# Altium schematic sheet Sheet2.SchDoc
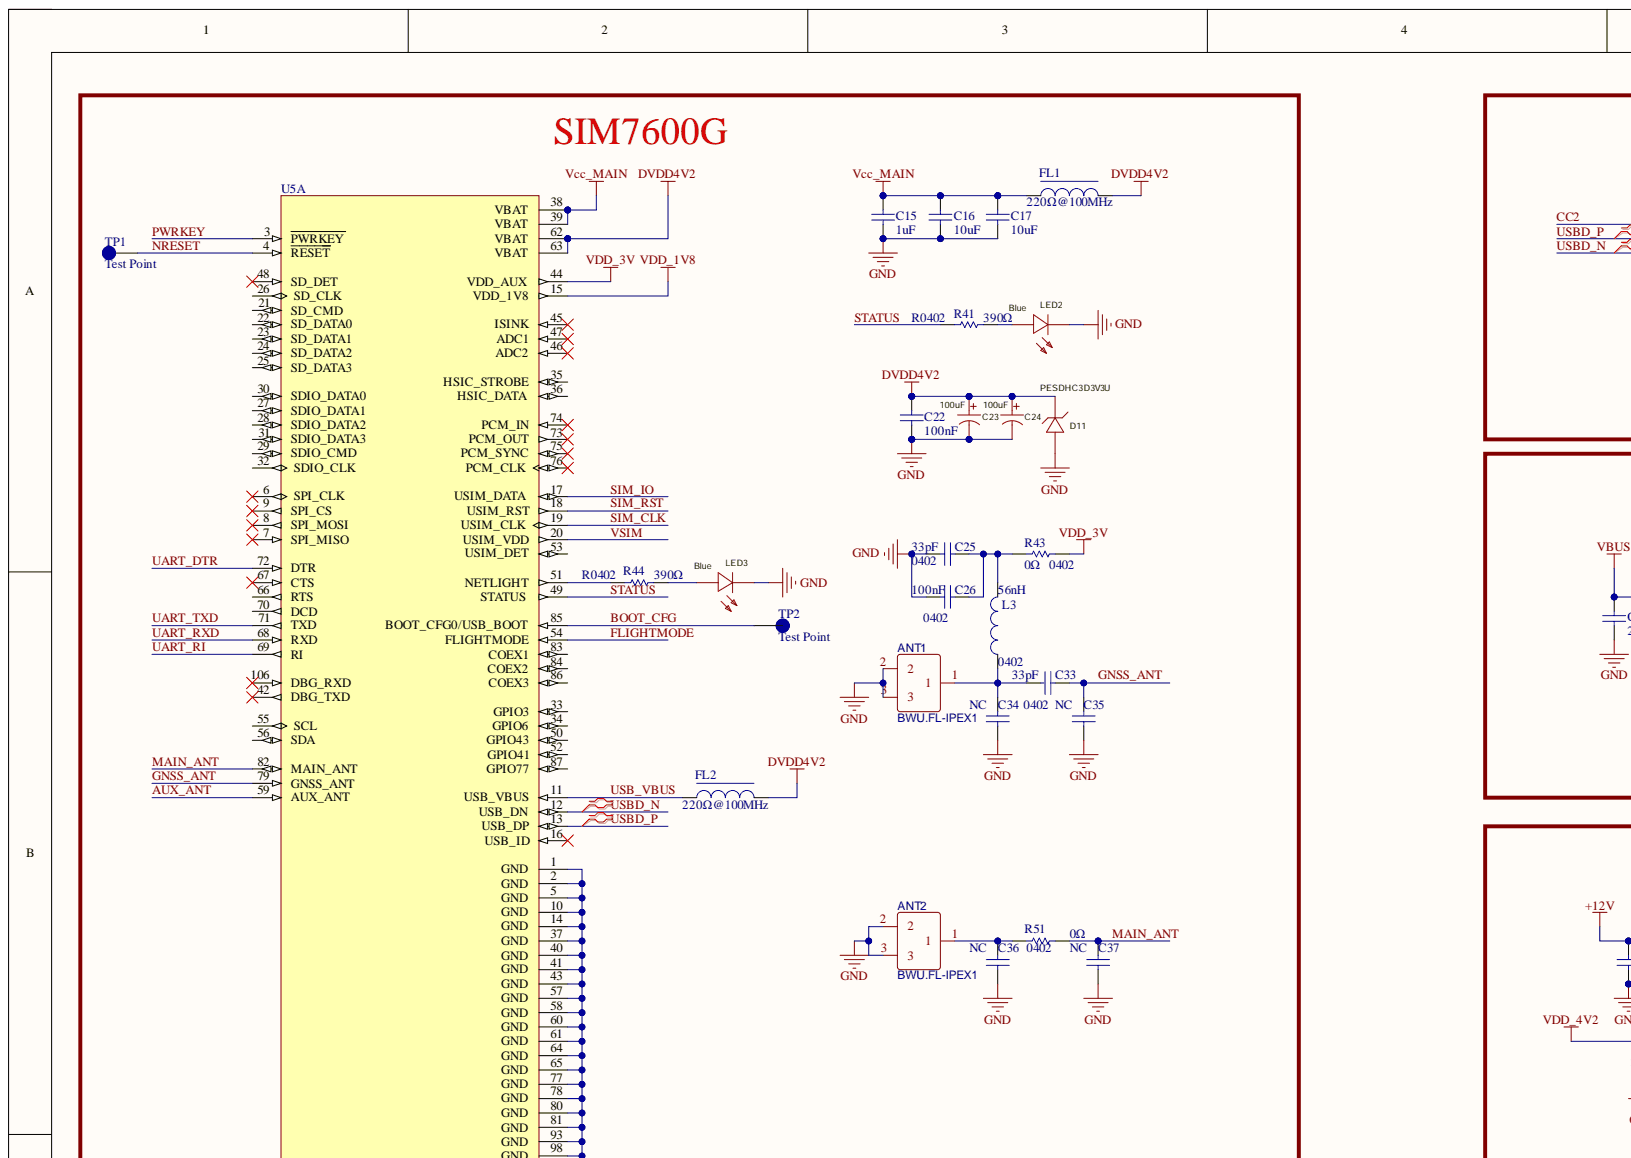
[diagram p0: Sheet2.SchDoc, top left]
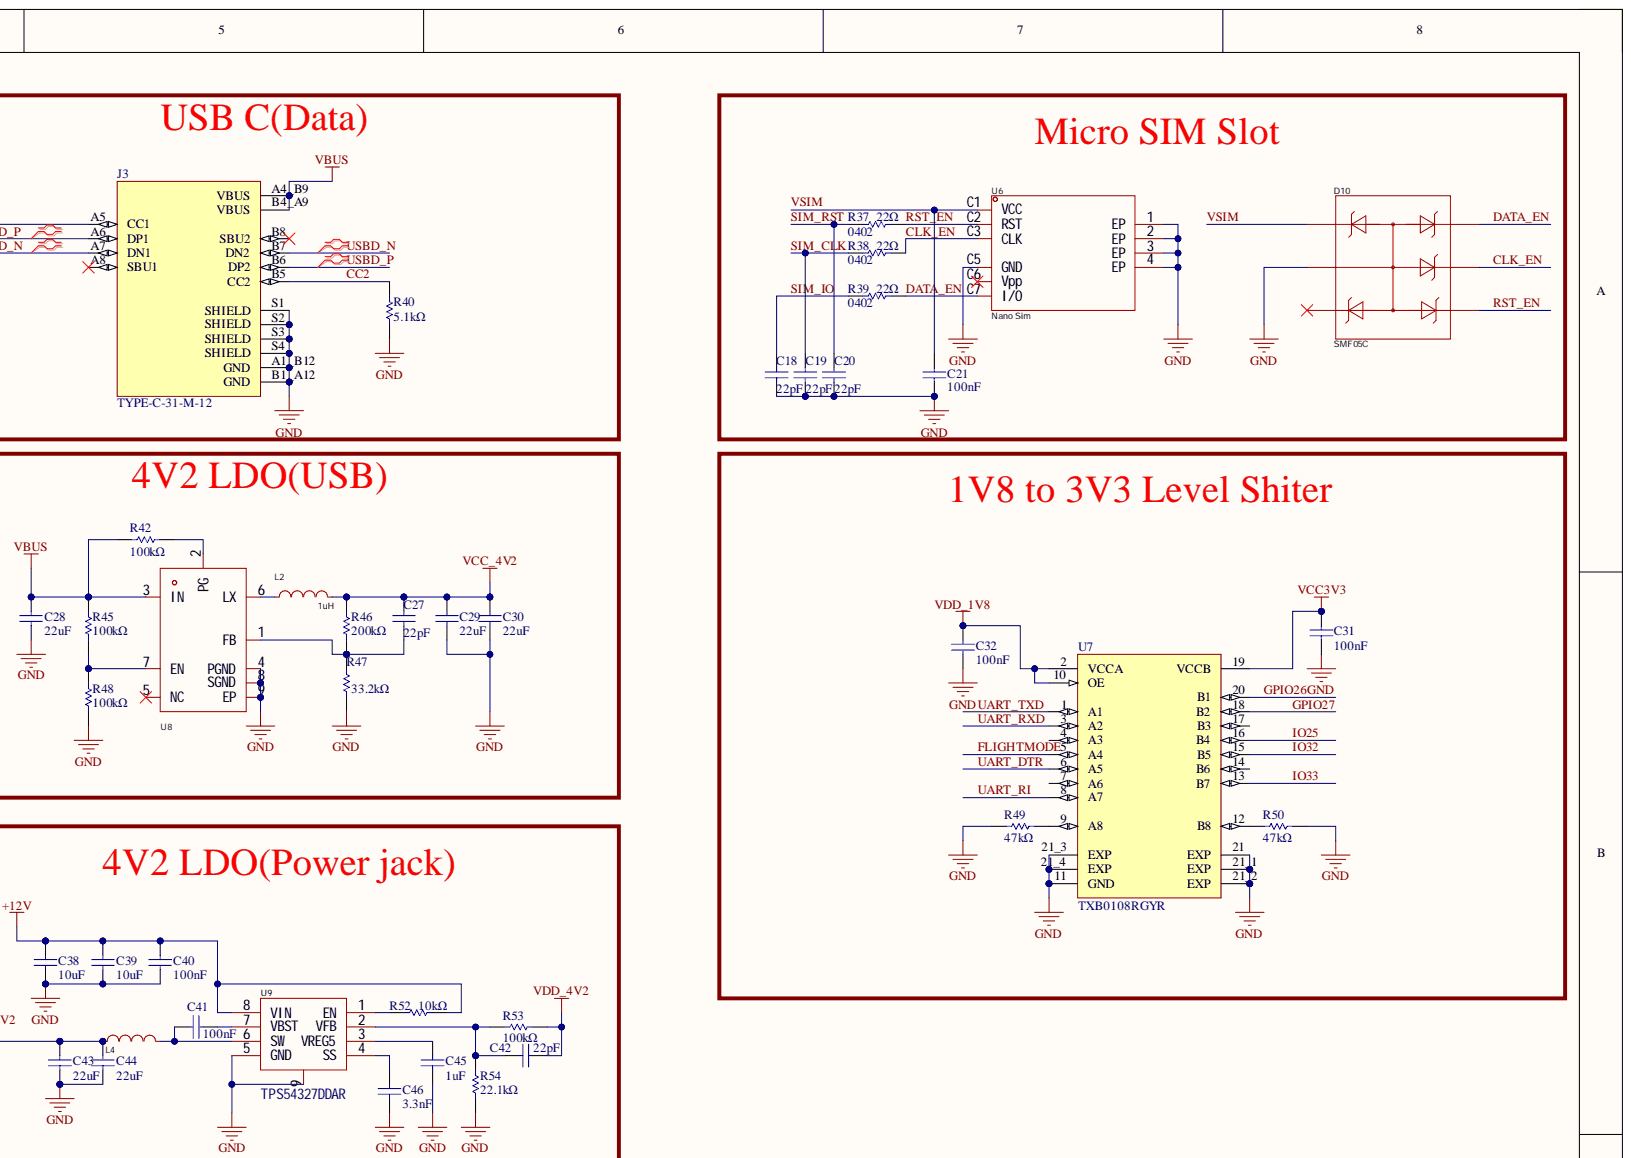
[diagram p1: Sheet2.SchDoc, top right]
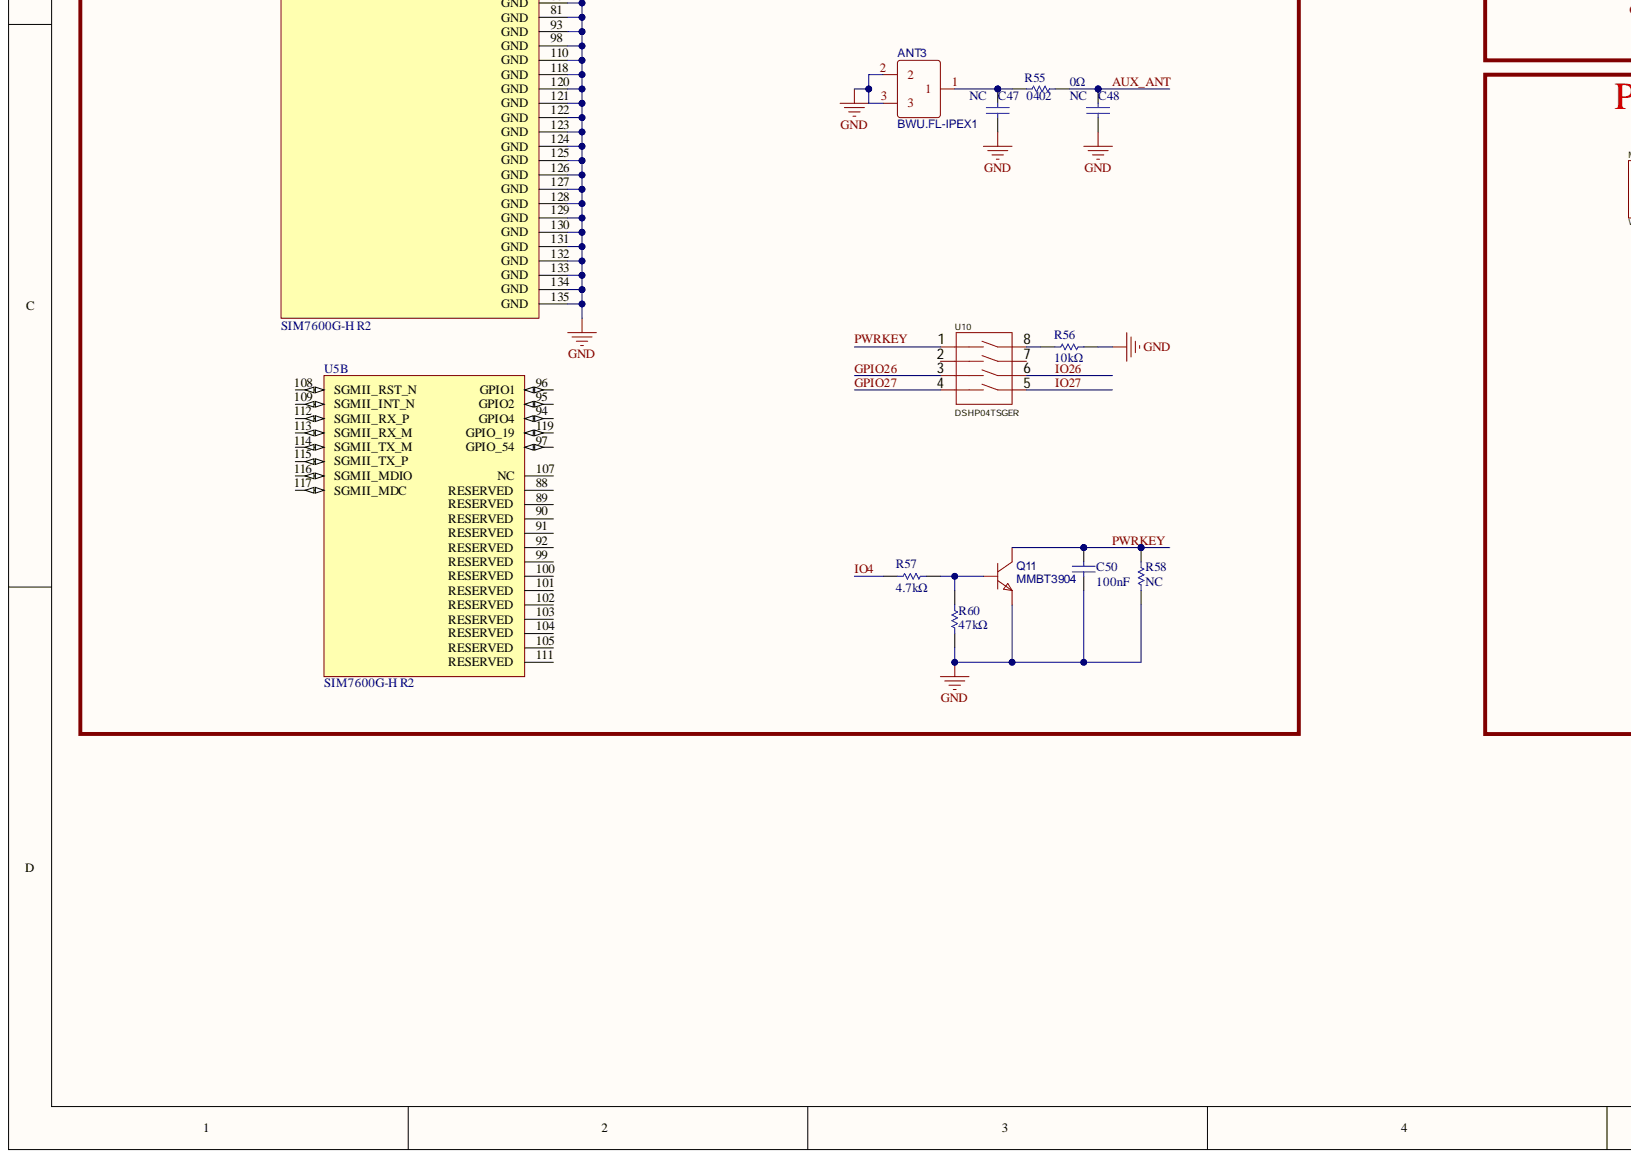
[diagram p2: Sheet2.SchDoc, bottom left]
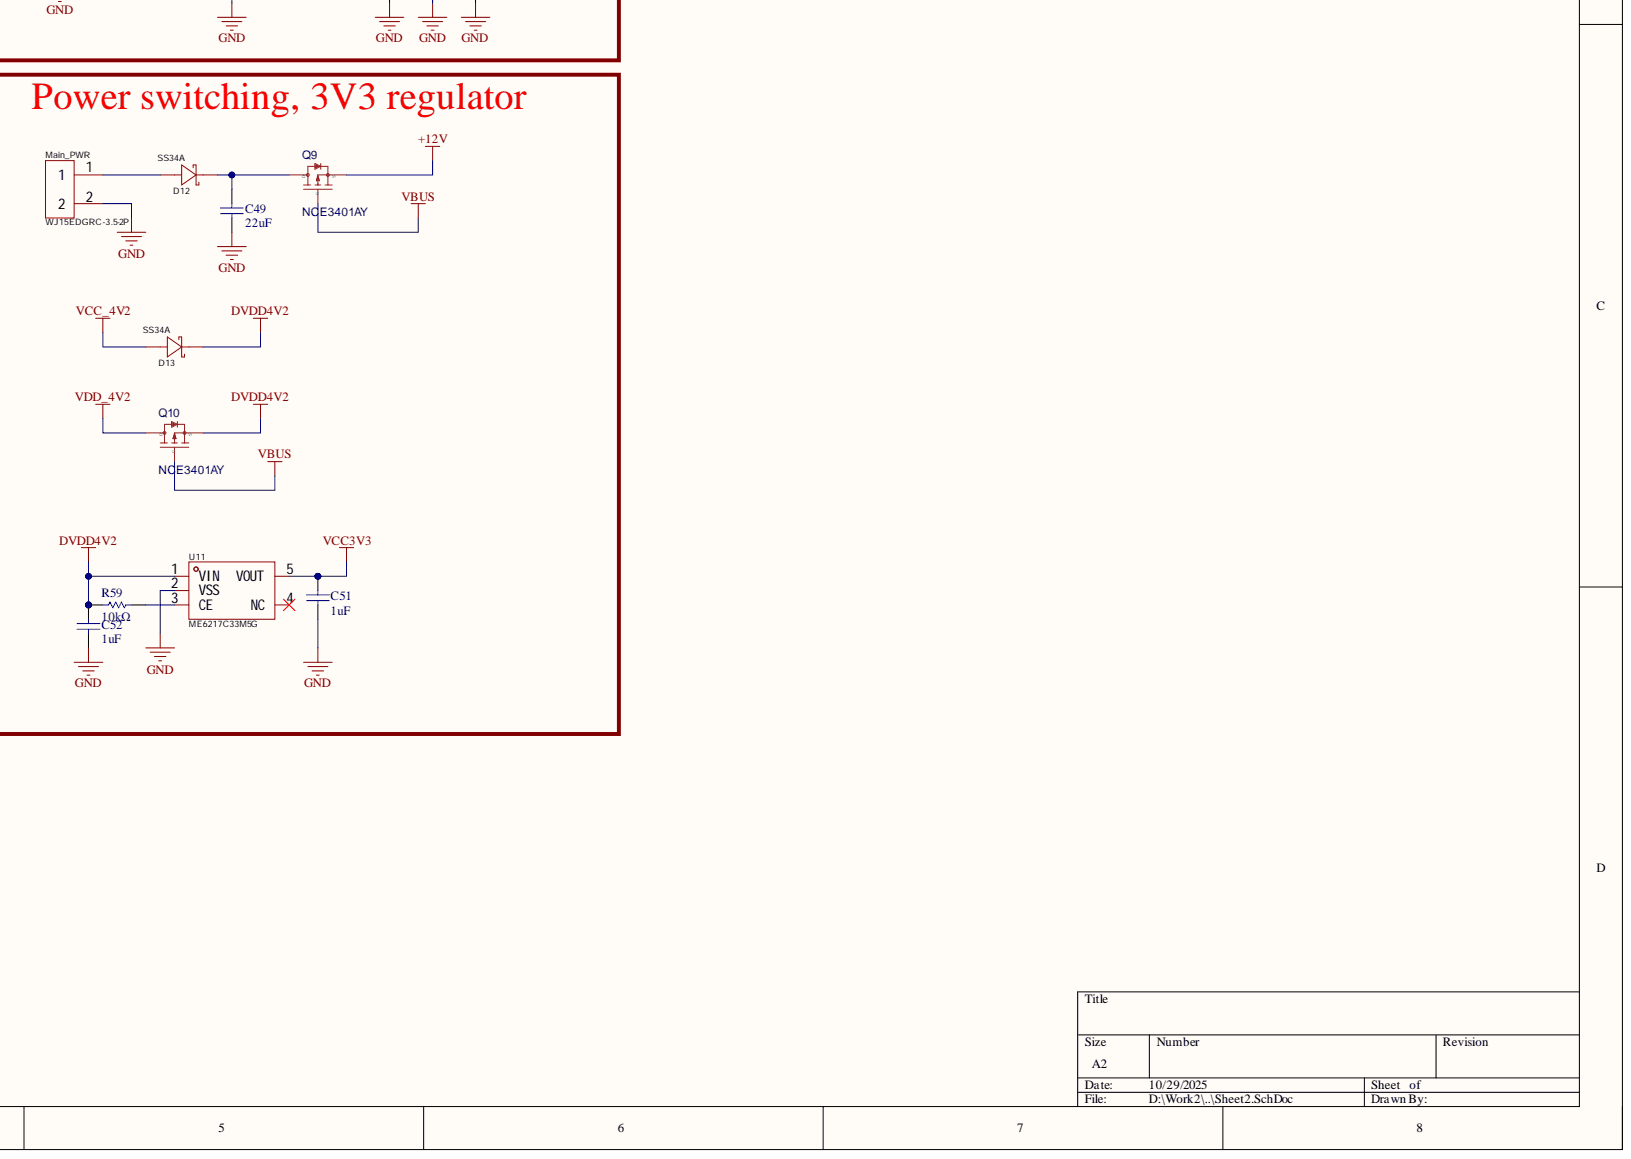
[diagram p3: Sheet2.SchDoc, bottom right]

## Parts
ANT1  BWU.FL-IPEX1  [IPEX-SMD_BWIPX-1-001E]
ANT2  BWU.FL-IPEX1  [IPEX-SMD_BWIPX-1-001E]
ANT3  BWU.FL-IPEX1  [IPEX-SMD_BWIPX-1-001E]
C15  value 1uF  [C0603]  (hidden comment: Cap)
C16  value 10uF  [C0603]  (hidden comment: Cap)
C17  value 10uF  [C0603]  (hidden comment: Cap)
C18  value 22pF  [C0603]  (hidden comment: Cap)
C19  value 22pF  [C0603]  (hidden comment: Cap)
C20  value 22pF  [C0603]  (hidden comment: Cap)
C21  value 100nF  [C0603]  (hidden comment: Cap)
C22  value 100nF  [C0603]  (hidden comment: Cap)
C23  100uF  [CAP-TH_BD6.3-P2.50-D1.0-FD]
C24  100uF  [CAP-TH_BD6.3-P2.50-D1.0-FD]
C25  value 33pF  Package: 0402  [C0402]  (hidden comment: Cap)
C26  value 100nF  C14663: 0402  [C0402]  (hidden comment: Cap)
C27  value 22pF  [C0603]  (hidden comment: Cap)
C28  value 22uF  [L0805]  (hidden comment: Cap)
C29  value 22uF  [L0805]  (hidden comment: Cap)
C30  value 22uF  [L0805]  (hidden comment: Cap)
C31  value 100nF  [C0603]  (hidden comment: Cap)
C32  value 100nF  [C0603]  (hidden comment: Cap)
C33  value 33pF  Package: 0402  [C0402]  (hidden comment: Cap)
C34  Mfr. Part #: NC  Package: 0402  [C0402]  (hidden comment: Cap)
C35  Mfr. Part #: NC  [C0402]  (hidden comment: Cap)
C36  Mfr. Part #: NC  [C0402]  (hidden comment: Cap)
C37  Mfr. Part #: NC  [C0402]  (hidden comment: Cap)
C38  value 10uF  [C0603]  (hidden comment: Cap)
C39  value 10uF  [C0603]  (hidden comment: Cap)
C40  value 100nF  [C0603]  (hidden comment: Cap)
C41  value 100nF  [C0603]  (hidden comment: Cap)
C42  value 22pF  [C0603]  (hidden comment: Cap)
C43  value 22uF  [L0805]  (hidden comment: Cap)
C44  value 22uF  [L0805]  (hidden comment: Cap)
C45  value 1uF  [C0603]  (hidden comment: Cap)
C46  value 3.3nF  [C0603]  (hidden comment: Cap)
C47  Mfr. Part #: NC  [C0402]  (hidden comment: Cap)
C48  Mfr. Part #: NC  [C0402]  (hidden comment: Cap)
C49  value 22uF  [L0805]  (hidden comment: Cap)
C50  value 100nF  [C0603]  (hidden comment: Cap)
C51  value 1uF  [C0603]  (hidden comment: Cap)
C52  value 1uF  [C0603]  (hidden comment: Cap)
D10  SMF05C  [SC-70-6_L2.0-W1.3-P0.65-LS2.1-BR]
D11  PESDHC3D3V3U  [SOD-323_L1.8-W1.3-LS2.5-RD]
D12  SS34A  [SMA_L4.2-W2.6-LS5.3-R-RD]
D13  SS34A  [SMA_L4.2-W2.6-LS5.3-R-RD]
FL1  value 220Ω@100MHz  [BEADC1608X95N]  (hidden comment: BLM18PG221SH1D)
FL2  value 220Ω@100MHz  [BEADC1608X95N]  (hidden comment: BLM18PG221SH1D)
J3  TYPE-C-31-M-12  [HRO_TYPE-C-31-M-12]
L2  1uH  [IND-SMD_L4.0-W4.0_SWPA4018S]
L3  value 56nH  Package: 0402  [L0402]
L4  3.3uH  [IND-SMD_L5.8-W5.2]  (value not drawn)
LED2  value Blue  [LED-SMD_L1.6-W0.8-RD-BLUE]  (hidden comment: EKINGLUX')
LED3  value Blue  [LED-SMD_L1.6-W0.8-RD-BLUE]  (hidden comment: EKINGLUX')
Main_PWR  WJ15EDGRC-3.5-2P  [CONN-TH_WJ15EDGRC-3.5-2P-14-00A]
Q9  NCE3401AY  [SOT-23_L2.9-W1.3-P1.90-LS2.4-BR]
Q10  NCE3401AY  [SOT-23_L2.9-W1.3-P1.90-LS2.4-BR]
Q11  MMBT3904  [SOT-23-3_L2.9-W1.3-P1.90-LS2.4-BR]
R37  value 22Ω  Package: 0402  [R0402]  (hidden comment: Res1)
R38  value 22Ω  Package: 0402  [R0402]  (hidden comment: Res1)
R39  value 22Ω  Package: 0402  [R0402]  (hidden comment: Res1)
R40  value 5.1kΩ  [R0603]  (hidden comment: Res1)
R41  R0402  value 390Ω  [R0402]
R42  value 100kΩ  [R0603]  (hidden comment: Res1)
R43  value 0Ω  Package: 0402  [R0402]  (hidden comment: Res1)
R44  R0402  value 390Ω  [R0402]
R45  value 100kΩ  [R0603]  (hidden comment: Res1)
R46  value 200kΩ  [R0603]  (hidden comment: Res1)
R47  value 33.2kΩ  [R0603]  (hidden comment: Res1)
R48  value 100kΩ  [R0603]  (hidden comment: Res1)
R49  value 47kΩ  [R0603]  (hidden comment: Res1)
R50  value 47kΩ  [R0603]  (hidden comment: Res1)
R51  value 0Ω  Package: 0402  [R0402]  (hidden comment: Res1)
R52  value 10kΩ  [R0603]  (hidden comment: Res1)
R53  value 100kΩ  [R0603]  (hidden comment: Res1)
R54  value 22.1kΩ  [R0603]  (hidden comment: Res1)
R55  value 0Ω  Package: 0402  [R0402]  (hidden comment: Res1)
R56  value 10kΩ  [R0603]  (hidden comment: Res1)
R57  value 4.7kΩ  [R0603]  (hidden comment: Res1)
R58  value NC  Mfr. Part #: NC  [R0603]  (hidden comment: Res1)
R59  value 10kΩ  [R0603]  (hidden comment: Res1)
R60  value 47kΩ  [R0603]  (hidden comment: Res1)
TP1  Test Point  [Test Point]  (no BOM)
TP2  Test Point  [Test Point]  (no BOM)
U5  SIM7600G-H R2  [IC_SIM7600G]  (parts A,B)
U6  value Nano Sim  [SIM-SMD_SMN-305]  (hidden comment: SMN-305)
U7  TXB0108RGYR  [IC_TXB0108RGYR]
U8  SY8003C1DFC  [WDFN-8_L2.0-W2.0-P0.50-BL-EP]  (value not drawn)
U9  TPS54327DDAR  [HSOP-8_L5.0-W4.0-P1.27-LS6.2-BL-EP]
U10  DSHP04TSGER  [SW-SMD_8P-L6.7-W5.4-P1.27-LS8.4]
U11  ME6217C33M5G  [SOT-23-5_L3.0-W1.7-P0.95-LS2.8-BR]

## Nets
+12V: C38.2 C39.2 C40.2 Q9.2 R52.1 U9.8(VIN)
DVDD4V2: C22.2 C23.1 C24.1 C52.2 D11.1 D13.2 FL1.2 FL2.2 Q10.2 R59.1 U5.62(VBAT) U5.63(VBAT) U11.1(VIN)
GND: ANT1.2 ANT1.3 ANT2.2 ANT2.3 ANT3.2 ANT3.3 C15.1 C16.1 C17.1 C18.2 C19.2 C20.2 C21.2 C22.1 C23.2 C24.2 C25.2 C26.2 C28.1 C29.1 C30.1 C31.2 C32.2 C34.2 C35.2 C36.2 C37.2 C38.1 C39.1 C40.1 C43.1 C44.1 C45.1 C46.1 C47.2 C48.2 C49.1 C50.2 C51.1 C52.1 D10.2 D11.2 J3.A1_B12(GND) J3.B1_A12(GND) J3.S1(SHIELD) J3.S2(SHIELD) J3.S3(SHIELD) J3.S4(SHIELD) LED2.1 LED3.1 Main_PWR.2 Q11.2 R40.1 R47.2 R48.1 R49.1 R50.2 R54.2 R56.2 R58.2 R60.2 U5.1(GND) U5.2(GND) U5.5(GND) U5.10(GND) U5.14(GND) U5.37(GND) U5.40(GND) U5.41(GND) U5.43(GND) U5.57(GND) U5.58(GND) U5.60(GND) U5.61(GND) U5.64(GND) U5.65(GND) U5.77(GND) U5.78(GND) U5.80(GND) U5.81(GND) U5.93(GND) U5.98(GND) U5.110(GND) U5.118(GND) U5.120(GND) U5.121(GND) U5.122(GND) U5.123(GND) U5.124(GND) U5.125(GND) U5.126(GND) U5.127(GND) U5.128(GND) U5.129(GND) U5.130(GND) U5.131(GND) U5.132(GND) U5.133(GND) U5.134(GND) U5.135(GND) U6.1(EP) U6.2(EP) U6.3(EP) U6.4(EP) U6.C5(GND) U7.11(GND) U7.21(EXP) U7.21_1(EXP) U7.21_2(EXP) U7.21_3(EXP) U7.21_4(EXP) U8.4(PGND) U8.8(SGND) U8.9(EP) U9.5(GND) U9.9 U11.2(VSS)
VBUS: C28.2 J3.A4_B9(VBUS) J3.B4_A9(VBUS) Q9.1 Q10.1 R42.2 R45.2 U8.3(IN)
VCC3V3: C31.1 C51.2 U7.19(VCCB) U11.5(VOUT)
VCC_4V2: C27.1 C29.2 C30.2 D13.1 L2.2 R46.1
Vcc_MAIN: C15.2 C16.2 C17.2 FL1.1 U5.38(VBAT) U5.39(VBAT)
VDD_1V8: C32.1 U5.15(VDD_1V8) U7.2(VCCA) U7.10(OE)
VDD_3V: R43.1 U5.44(VDD_AUX)
VDD_4V2: C42.2 C43.2 C44.2 L4.1 Q10.3 R53.1
AUX_ANT: C48.1 R55.1 U5.59(AUX_ANT)
BOOT_CFG: TP2.TP U5.85(BOOT_CFG0/USB_BOOT)
CC2: J3.A5(CC1) J3.B5(CC2) R40.2
CLK_EN: D10.5 R38.1 U6.C3(CLK)
DATA_EN: D10.6 R39.1 U6.C7(I/O)
FLIGHTMODE: U5.54(FLIGHTMODE) U7.5(A4)
GNSS_ANT: C33.1 C35.1 U5.79(GNSS_ANT)
GPIO26: U7.20(B1) U10.3
GPIO27: U7.18(B2) U10.4
IO4: R57.2
IO25: U7.16(B4)
IO26: U10.6
IO27: U10.5
IO32: U7.15(B5)
IO33: U7.13(B7)
MAIN_ANT: C37.1 R51.1 U5.82(MAIN_ANT)
NRESET: TP1.TP U5.4(RESET)
PWRKEY: C50.1 Q11.3 R58.1 U5.3(PWRKEY) U10.1
RST_EN: D10.4 R37.1 U6.C2(RST)
SIM_CLK: C19.1 R38.2 U5.19(USIM_CLK)
SIM_IO: C18.1 R39.2 U5.17(USIM_DATA)
SIM_RST: C20.1 R37.2 U5.18(USIM_RST)
STATUS: R41.2 U5.49(STATUS)
UART_DTR: U5.72(DTR) U7.6(A5)
UART_RI: U5.69(RI) U7.8(A7)
UART_RXD: U5.68(RXD) U7.3(A2)
UART_TXD: U5.71(TXD) U7.1(A1)
USB_VBUS: FL2.1 U5.11(USB_VBUS)
USBD_N: J3.A7(DN1) J3.B7(DN2) U5.12(USB_DN)
USBD_P: J3.A6(DP1) J3.B6(DP2) U5.13(USB_DP)
VSIM: C21.1 D10.1 U5.20(USIM_VDD) U6.C1(VCC)
NetANT1_1: ANT1.1 C33.2 C34.1 L3.1
NetANT2_1: ANT2.1 C36.1 R51.2
NetANT3_1: ANT3.1 C47.1 R55.2
NetC25_1: C25.1 C26.1 L3.2 R43.2
NetC27_2: C27.2 R46.2 R47.1 U8.1(FB)
NetC41_1: C41.1 U9.7(VBST)
NetC41_2: C41.2 L4.2 U9.6(SW)
NetC42_1: C42.1 R53.2 R54.1 U9.2(VFB)
NetC45_2: C45.2 U9.3(VREG5)
NetC46_2: C46.2 U9.4(SS)
NetC49_2: C49.2 D12.2 Q9.3
NetD12_1: D12.1 Main_PWR.1
NetL2_1: L2.1 U8.6(LX)
NetLED2_2: LED2.2 R41.1
NetLED3_2: LED3.2 R44.1
NetQ11_1: Q11.1 R57.1 R60.1
NetR42_1: R42.1 U8.2(PG)
NetR44_2: R44.2 U5.51(NETLIGHT)
NetR45_1: R45.1 R48.2 U8.7(EN)
NetR49_2: R49.2 U7.9(A8)
NetR50_1: R50.1 U7.12(B8)
NetR52_2: R52.2 U9.1(EN)
NetR56_1: R56.1 U10.8
NetR59_2: R59.2 U11.3(CE)

Not connected: D10 3; J3 A8(SBU1) B8(SBU2); U5 6(SPI_CLK) 7(SPI_MISO) 8(SPI_MOSI) 9(SPI_CS) 16(USB_ID) 21(SD_CMD) 22(SD_DATA0) 23(SD_DATA1) 24(SD_DATA2) 25(SD_DATA3) 26(SD_CLK) 27(SDIO_DATA1) 28(SDIO_DATA2) 29(SDIO_CMD) 30(SDIO_DATA0) 31(SDIO_DATA3) 32(SDIO_CLK) 33(GPIO3) 34(GPIO6) 35(HSIC_STROBE) 36(HSIC_DATA) 42(DBG_TXD) 45(ISINK) 46(ADC2) 47(ADC1) 48(SD_DET) 50(GPIO43) 52(GPIO41) 53(USIM_DET) 55(SCL) 56(SDA) 66(RTS) 67(CTS) 70(DCD) 73(PCM_OUT) 74(PCM_IN) 75(PCM_SYNC) 76(PCM_CLK) 83(COEX1) 84(COEX2) 86(COEX3) 87(GPIO77) 88(RESERVED) 89(RESERVED) 90(RESERVED) 91(RESERVED) 92(RESERVED) 94(GPIO4) 95(GPIO2) 96(GPIO1) 97(GPIO_54) 99(RESERVED) 100(RESERVED) 101(RESERVED) 102(RESERVED) 103(RESERVED) 104(RESERVED) 105(RESERVED) 106(DBG_RXD) 107(NC) 108(SGMII_RST_N) 109(SGMII_INT_N) 111(RESERVED) 112(SGMII_RX_P) 113(SGMII_RX_M) 114(SGMII_TX_M) 115(SGMII_TX_P) 116(SGMII_MDIO) 117(SGMII_MDC) 119(GPIO_19); U6 C6(Vpp); U7 4(A3) 7(A6) 14(B6) 17(B3); U8 5(NC); U10 2 7; U11 4(NC)

## Library data of the parts
ANT1-ANT3 = BWU.FL-IPEX1: Manufacturer: BAT WIRELESS(蝙蝠无线); Value: GNSS; Component Kind: Standard; JLCPCB Part #: C5137195; Library Name: Schlib1.SchLib; MFR.Part #: BWU.FL-IPEX1; Package: SMD
C15 C45 C51 C52 = Cap: Capacitor; Manufacturer: Samsung Electro-Mechanics; Mfr. Part #: CL10A105KO8NNNC; C14663: 0603; Component Kind: Standard; LCSC Part #: C1592; Library Name: Miscellaneous Devices.IntLib; Signal Integrity: Cap; Simulation: CAP
C16 C17 C38 C39 = Cap: Capacitor; Manufacturer: Samsung Electro-Mechanics; Mfr. Part #: CL10A106MA8NRNC; C14663: 0603; Component Kind: Standard; LCSC Part #: C96446; Library Name: Miscellaneous Devices.IntLib; Signal Integrity: Cap; Simulation: CAP
C18-C20 C27 C42 = Cap: Capacitor; Manufacturer: Samsung Electro-Mechanics; Mfr. Part #: CL10C220JB8NNNC; C14663: 0603; Component Kind: Standard; LCSC Part #: C1653; Library Name: Miscellaneous Devices.IntLib; Signal Integrity: Cap; Simulation: CAP
C21 C22 C31 C32 C40 C41 C50 = Cap: Capacitor; Manufacturer: YAGEO; Mfr. Part #: CC0603KRX7R9BB104; C14663: 0603; Component Kind: Standard; LCSC Part #: C14663; Library Name: Miscellaneous Devices.IntLib; Signal Integrity: Cap; Simulation: CAP
C23 C24 = KM107M035E11RR0VH2FP0: Manufacturer: CX(Dongguan Chengxing Elec); Component Kind: Standard; LCSC Part #: C19504; Library Name: Schlib1.SchLib; Mfr. Part #: KM107M035E11RR0VH2FP0; Package: Plugin,D6.3xL11mm; PCB3D: CAP-TH_BD6.3-P2.50-D1.0-FD; Value: 100uF
C25 C33 = Cap: Capacitor; Manufacturer: FH (Guangdong Fenghua Advanced Tech); Mfr. Part #: 0402CG330J500NT; Component Kind: Standard; LCSC Part #: C1562; Library Name: Miscellaneous Devices.IntLib; Signal Integrity: Cap; Simulation: CAP
C26 = Cap: Capacitor; Manufacturer: Samsung Electro-Mechanics; Mfr. Part #: CL05B104KO5NNNC; Component Kind: Standard; LCSC Part #: C1525; Library Name: Miscellaneous Devices.IntLib; Signal Integrity: Cap; Simulation: CAP
C28-C30 C43 C44 C49 = Cap: Capacitor; Manufacturer: Samsung Electro-Mechanics; Mfr. Part #: CL21A226MAQNNNE; C14663: 0805; Component Kind: Standard; LCSC Part #: C45783; Library Name: Miscellaneous Devices.IntLib; Signal Integrity: Cap; Simulation: CAP
C34-C37 C47 C48 = Cap: Capacitor; Manufacturer: FH (Guangdong Fenghua Advanced Tech); Component Kind: Standard; Library Name: Miscellaneous Devices.IntLib; Signal Integrity: Cap; Simulation: CAP
  C35-C37 C47 C48: Package: 0402
C46 = Cap: Capacitor; Manufacturer: Samsung Electro-Mechanics; Mfr. Part #: CL10B332KB8NNNC; C14663: 0603; Component Kind: Standard; LCSC Part #: C1613; Library Name: Miscellaneous Devices.IntLib; Signal Integrity: Cap; Simulation: CAP
D10 = SMF05C_C558425: Manufacturer: TECH PUBLIC; Value: TVS/ESD; Component Kind: Standard; JLCPCB Part #: C558425; Library Name: Schlib1.SchLib; MFR.Part #: SMF05C; Package: SOT-363; PCB3D: SC-70-6_L2.0-W1.3-H1.0-P0.65
D11 = PESDHC3D3V3U: Manufacturer: Shanghai Prisemi Elec; Value: TVS/ESD; Component Kind: Standard; JLCPCB Part #: C496538; Library Name: Schlib1.SchLib; MFR.Part #: PESDHC3D3V3U; Package: SOD-323; PCB3D: SOD-323_L1.7-W1.3-H1.1-LS2.5
D12 D13 = SS34A_C2978778: Manufacturer: EIC Semicon; Value: 0.5V@3A; Component Kind: Standard; LCSC Part #: C2978778; Library Name: Schlib1.SchLib; Mfr. Part #: SS34A; Package: DO-214AC(SMA); PCB3D: SMA_L4.2-W2.6-LS5.3-R-RD
FL1 FL2 = BLM18PG221SH1D: FERRITE BEAD 220 OHM 0603 1LN; Manufacturer: Murata Electronics; Component Kind: Standard; LCSC Part #: C711569; Library Name: Schlib1.SchLib; Mfr. Part #: BLM18PG221SH1D; Package: 0603
J3 = TYPE-C-31-M-12: USB Connectors 24 Receptacle 1 8.94*7.3mm RoHS; Manufacturer: Korean Hroparts Elec; Component Kind: Standard; LCSC Part #: C165948; Library Name: Schlib1.SchLib; Mfr. Part #: TYPE-C-31-M-12; Package: Type-C
L2 = SWPA4030S1R0NT: Manufacturer: Sunlord; Component Kind: Standard; JLCPCB Part #: C42193; Library Name: Schlib1.SchLib; MFR.Part #: SWPA4030S1R0NT; Package: SMD,4x4mm; PCB3D: IND-SMD_L4.0-W4.0-H3.0; Value: 1uH
L3 = LQW15AN1N5B00D: Inductor with Inductance: 1.5nH Tol. +/-0.1nH, Package: 0402 (1005); Manufacturer: TDK; Mfr. Part #: MLG1005S56NJT000; Component Kind: Standard; LCSC Part #: C76777; Library Name: Schlib1.SchLib
L4 = SM5845-3R3MT: Manufacturer: SXN(顺翔诺); Component Kind: Standard; JLCPCB Part #: C50379; Library Name: Schlib1.SchLib; MFR.Part #: SM5845-3R3MT; Package: SMD,5.8x5.2mm; PCB3D: IND-SMD_L5.8-W5.2-H4.5; Value: 3.3uH
LED2 LED3 = E6Q0603QBAC1UDA: Component Kind: Standard; LCSC Part #: C375575; Library Name: Schlib1.SchLib; Manufacturer: EKINGLUX; Mfr. Part #: E6Q0603QBAC1UDA; Package: 0603
Main_PWR = WJ15EDGRC-3.5-2P_C61791: https://es.aliexpress.com/item/1005005511990041.html?spm=a2g0o.productlist.main.3.444dHbQMHbQMQW&algo_pvid=eebe03df-903d-4a01-8bcf-3ccdb62df07f&algo_exp_id=eebe03df-903d-4a01-8bcf-3ccdb62df07f-1&pdp_ext_f=%7B%22order%22%3A%221248%22%2C%22eval%22%3A%221%22%7D&pdp_npi=4%40dis%21EUR%212.01%211.89%21%21%212.04%211.92%21%402103890917374691080141047e4ab9%2112000033379333548%21sea%21DE%21709413394%21X&curPageLogUid=aJgEfep2J8j6&utparam-url=scene%3Asearch%7Cquery_from%3A; Manufacturer: Ningbo Kangnex Elec; Value: 2P; Component Kind: Standard; LCSC Part #: C61791; Library Name: Schlib1.SchLib; Mfr. Part #: WJ15EDGRC-3.5-2P; Package: Push-Pull,P=3.5mm; PCB3D: CONN-TH_WJ15EDGRC-3.5-2P-14-00A
Q9 Q10 = NCE3401AY: Manufacturer: NCE(无锡新洁能); Value: P-Chanel; Component Kind: Standard; LCSC Part #: C169815; Library Name: Schlib1.SchLib; Mfr. Part #: NCE3401AY; Package: SOT-23
Q11 = MMBT3904-C20526: Manufacturer: Jiangsu Changjing Electronics Technology Co., Ltd.; Mfr. Part #: MMBT3904(RANGE:100-300); Component Kind: Standard; LCSC Part #: C20526; Library Name: Schlib1.SchLib; Package: SOT-23
R37-R39 = Res1: Resistor; Manufacturer: UNI-ROYAL(Uniroyal Elec); Mfr. Part #: 0402WGF220JTCE; Component Kind: Standard; LCSC Part #: C25092; Library Name: Miscellaneous Devices.IntLib; Signal Integrity: Res; Simulation: RESISTOR
R40 = Res1: Resistor; Manufacturer: UNI-ROYAL(Uniroyal Elec); Mfr. Part #: 0603WAF5101T5E; Component Kind: Standard; LCSC Part #: C23186; Library Name: Miscellaneous Devices.IntLib; Package: 0603; Signal Integrity: Res; Simulation: RESISTOR
R41 R44 = Res1: Resistor; Manufacturer: UNI-ROYAL(Uniroyal Elec); Mfr. Part #: 0402WGJ0391TCE; Component Kind: Standard; LCSC Part #: C25163; Library Name: Miscellaneous Devices.IntLib; Package: 0402; Signal Integrity: Res; Simulation: RESISTOR
R42 R45 R48 R53 = Res1: Resistor; Manufacturer: UNI-ROYAL(Uniroyal Elec); Mfr. Part #: 0603WAJ0104T5E; Component Kind: Standard; LCSC Part #: C15458; Library Name: Miscellaneous Devices.IntLib; Package: 0603; Signal Integrity: Res; Simulation: RESISTOR
R43 R51 R55 = Res1: Resistor; Manufacturer: UNI-ROYAL(Uniroyal Elec); Mfr. Part #: 0402WGF0000TCE; Component Kind: Standard; LCSC Part #: C17168; Library Name: Miscellaneous Devices.IntLib; Signal Integrity: Res; Simulation: RESISTOR
R46 = Res1: Resistor; Manufacturer: UNI-ROYAL(Uniroyal Elec); Mfr. Part #: 0603WAF2003T5E; Component Kind: Standard; LCSC Part #: C25811; Library Name: Miscellaneous Devices.IntLib; Package: 0603; Signal Integrity: Res; Simulation: RESISTOR
R47 = Res1: Resistor; Manufacturer: UNI-ROYAL(Uniroyal Elec); Mfr. Part #: 0603WAF3322T5E; Component Kind: Standard; LCSC Part #: C23003; Library Name: Miscellaneous Devices.IntLib; Package: 0603; Signal Integrity: Res; Simulation: RESISTOR
R49 R50 R60 = Res1: Resistor; Manufacturer: UNI-ROYAL(Uniroyal Elec); Mfr. Part #: 0603WAJ0473T5E; Component Kind: Standard; LCSC Part #: C25600; Library Name: Miscellaneous Devices.IntLib; Package: 0603; Signal Integrity: Res; Simulation: RESISTOR
R52 R56 R59 = Res1: Resistor; Manufacturer: UNI-ROYAL(Uniroyal Elec); Mfr. Part #: 0603WAJ0103T5E; Component Kind: Standard; LCSC Part #: C15401; Library Name: Miscellaneous Devices.IntLib; Package: 0603; Signal Integrity: Res; Simulation: RESISTOR
R54 = Res1: Resistor; Manufacturer: UNI-ROYAL(Uniroyal Elec); Mfr. Part #: 0603WAF2212T5E; Component Kind: Standard; LCSC Part #: C25961; Library Name: Miscellaneous Devices.IntLib; Package: 0603; Signal Integrity: Res; Simulation: RESISTOR
R57 = Res1: Resistor; Manufacturer: UNI-ROYAL(Uniroyal Elec); Mfr. Part #: 0603WAF4701T5E; Component Kind: Standard; LCSC Part #: C23162; Library Name: Miscellaneous Devices.IntLib; Package: 0603; Signal Integrity: Res; Simulation: RESISTOR
R58 = Res1: Resistor; Component Kind: Standard; Library Name: Miscellaneous Devices.IntLib; Package: 0603; Signal Integrity: Res; Simulation: RESISTOR
TP1 TP2 = Test Point: SMT Test Point- Mininature-1000 pc Reels; Library Name: Schlib1.SchLib
U5 = SIM7600G: LTE Cat-4 Module; Manufacturer: SIMCOM WIRELESS SOLUTIONS LIMITED; Component Kind: Standard; LCSC Part #: C5189046; Library Name: Schlib1.SchLib; Mfr. Part #: SIM7600G-H R2; Package: SMD-135
U6 = SMN-305: Manufacturer: XUNPU(讯普); Component Kind: Standard; LCSC Part #: C266890; Library Name: Schlib1.SchLib; Mfr. Part #: SMN-305; Package: SMD; PCB3D: SIM-SMD_SMN-305
U7 = TXB0108RGYR: 8-Bit Bidirectional Voltage-Level Shifter with Auto Direction Sensing and +/-15-kV ESD Protect 20-VQFN -40 to 85; Manufacturer: Texas Instruments; Value: None; Component Kind: Standard; LCSC Part #: C194701; Library Name: Schlib1.SchLib; Mfr. Part #: TXB0108RGYR; Package: VQFN-20-EP(3.5x4.5)
U8 = SY8003C1DFC: Manufacturer: Silergy Corp; Value: 3A , 4.2V; Component Kind: Standard; LCSC Part #: C41407207; Library Name: Schlib1.SchLib; Mfr. Part #: SY8003C1DFC; Package: DFN-8-EP(2x2); PCB3D: WDFN-8_L2.0-W2.0-H0.8-P0.50
U9 = TPS54327DDAR: Manufacturer: Texas Instruments; Value: 4.2V, 3A; Component Kind: Standard; LCSC Part #: C66602; Library Name: Schlib1.SchLib; Mfr. Part #: TPS54327DDAR; Package: HSOP-8-EP; PCB3D: HSOP-8_L4.9-W3.9-H1.8-LS6.0-P1.27
U10 = DSHP04TSGER_C3293144: Manufacturer: kangshen; Value: Black; Component Kind: Standard; LCSC Part #: C3293144; Library Name: Schlib1.SchLib; Mfr. Part #: DSHP04TSGER; Package: SMD-8P,5.4x6.6mm; PCB3D: SW-SMD_8P-L6.7-W5.4-H2.3-LS8.4-P1.27
U11 = ME6217C33M5G: Manufacturer: MICRONE(Nanjing Micro One Elec); Value: 800mA Fixed 3.3V; Component Kind: Standard; LCSC Part #: C427602; Library Name: Schlib1.SchLib; Mfr. Part #: ME6217C33M5G; Package: SOT-23-5; PCB3D: SOT-23-5_L2.9-W1.6-H1.1-LS2.8-P0.95
